# Altium schematic sheet RC_Board.SchDoc(RC_Board)
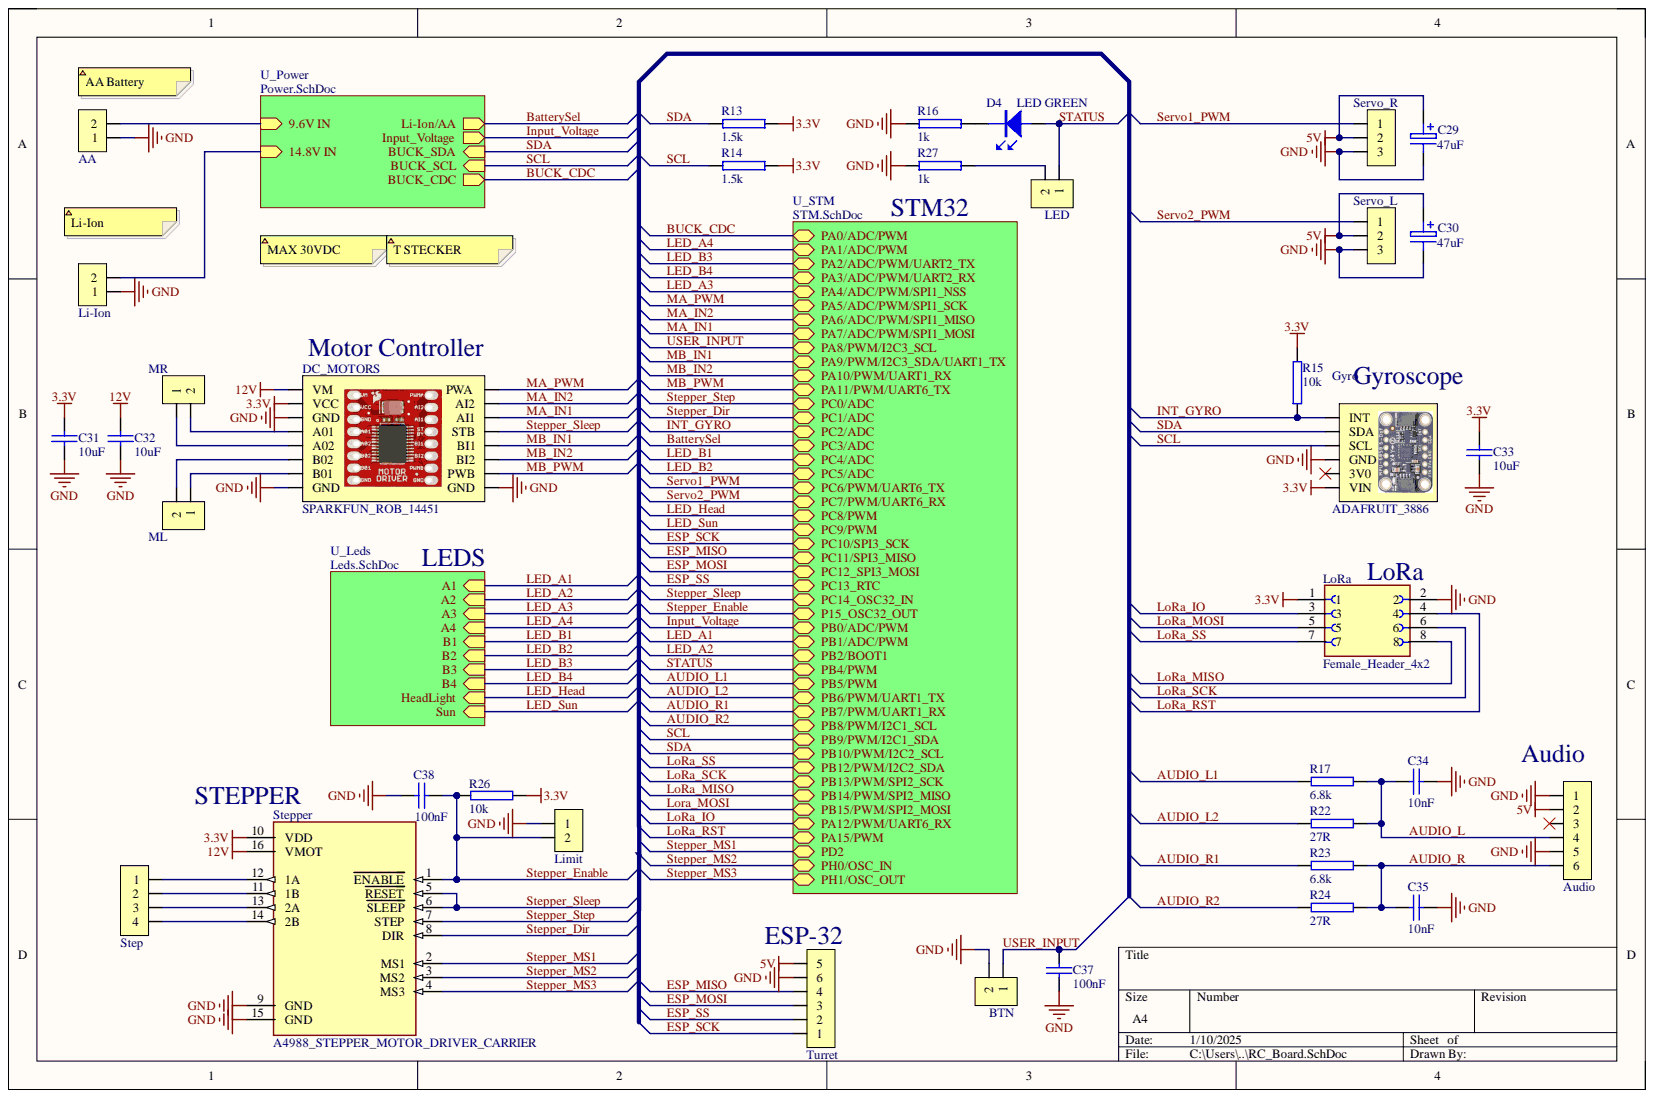
[diagram p0: RC_Board.SchDoc]

## Parts
AA  2Pin_Female  [OSTTE020104]  (value not drawn)
Audio  6Pin_Male  [Header1x6_254]  (value not drawn)
BTN  2Pin_Male  [Header1x2_254]  (value not drawn)
C29  value 47uF  [HA1C470WR]  (hidden comment: C 47uF ALUM)
C30  value 47uF  [HA1C470WR]  (hidden comment: C 47uF ALUM)
C31  value 10uF  [0603]  (hidden comment: C 10uF 0603)
C32  value 10uF  [0603]  (hidden comment: C 10uF 0603)
C33  value 10uF  [0603]  (hidden comment: C 10uF 0603)
C34  value 10nF  [0603]  (hidden comment: C 10nF 0603)
C35  value 10nF  [0603]  (hidden comment: C 10nF 0603)
C37  value 100nF  [0603]  (hidden comment: C 100nF 0603)
C38  value 100nF  [0603]  (hidden comment: C 100nF 0603)
D4  LED GREEN  [LED_1206]
DC_MOTORS  SPARKFUN_ROB_14451  [SPARKFUN_ROB_14451]
Gyro  ADAFRUIT_3886  [ADAFRUIT_3886]
LED  2Pin_Male  [Header1x2_254]  (value not drawn)
Li-Ion  2Pin_Female  [OSTTE020104]  (value not drawn)
Limit  2Pin_Male  [Header1x2_254]  (value not drawn)
LoRa  Female_Header_4x2  [SULLINS_PPPC042LFBN-RC]
ML  2Pin_Male  [Header1x2_254]  (value not drawn)
MR  2Pin_Male  [Header1x2_254]  (value not drawn)
R13  value 1.5k  [0805]  (hidden comment: R 1K5 0805)
R14  value 1.5k  [0805]  (hidden comment: R 1K5 0805)
R15  value 10k  [0805]  (hidden comment: R 10K 0805)
R16  value 1k  [0805]  (hidden comment: R 1K 0805)
R17  value 6.8k  [0805]  (hidden comment: R 6K8 0805)
R22  value 27R  [0805]  (hidden comment: R 27R 0805)
R23  value 6.8k  [0805]  (hidden comment: R 6K8 0805)
R24  value 27R  [0805]  (hidden comment: R 27R 0805)
R26  value 10k  [0805]  (hidden comment: R 10K 0805)
R27  value 1k  [0805]  (hidden comment: R 1K 0805)
Servo_L  3Pin_Male  [Header1x3_254]  (value not drawn)
Servo_R  3Pin_Male  [Header1x3_254]  (value not drawn)
Step  4Pin_Male  [Header1x4_254]  (value not drawn)
Stepper  A4988_STEPPER_MOTOR_DRIVER_CARRIER  [MODULE_A4988_STEPPER_MOTOR_DRIVER_CARRIER]
Turret  6Pin_Male  [Header1x6_254]  (value not drawn)

## Nets
3.3V: C31.1 C33.1 DC_MOTORS.2(VCC) Gyro.1(VIN) LoRa.1 R13.2 R14.2 R15.2 R26.2 Stepper.10(VDD)
5V: Audio.2 C29.1 C30.1 Servo_L.2 Servo_R.2 Turret.5
12V: C32.1 DC_MOTORS.1(VM) Stepper.16(VMOT)
GND: AA.1 Audio.1 Audio.5 BTN.2 C29.2 C30.2 C31.2 C32.2 C33.2 C34.2 C35.2 C37.2 C38.1 DC_MOTORS.3(GND) DC_MOTORS.8(GND) DC_MOTORS.9(GND) Gyro.3(GND) Li-Ion.1 Limit.1 LoRa.2 R16.1 R27.1 Servo_L.3 Servo_R.3 Stepper.9(GND) Stepper.15(GND) Turret.6
AUDIO_L: Audio.4 C34.1 R17.2 R22.2
AUDIO_L1: R17.1
AUDIO_L2: R22.1
AUDIO_R: Audio.6 C35.1 R23.2 R24.2
AUDIO_R1: R23.1
AUDIO_R2: R24.1
ESP_MISO: Turret.4
ESP_MOSI: Turret.3
ESP_SCK: Turret.1
ESP_SS: Turret.2
INT_GYRO: Gyro.6(INT) R15.1
LoRa_IO: LoRa.3
LoRa_MISO: LoRa.8
Lora_MOSI: LoRa.5
LoRa_RST: LoRa.4
LoRa_SCK: LoRa.6
LoRa_SS: LoRa.7
MA_IN1: DC_MOTORS.14(AI1)
MA_IN2: DC_MOTORS.15(AI2)
MA_PWM: DC_MOTORS.16(PWA)
MB_IN1: DC_MOTORS.12(BI1)
MB_IN2: DC_MOTORS.11(BI2)
MB_PWM: DC_MOTORS.10(PWB)
SCL: Gyro.4(SCL) R14.1
SDA: Gyro.5(SDA) R13.1
Servo1_PWM: Servo_R.1
Servo2_PWM: Servo_L.1
STATUS: D4.A LED.1
Stepper_Dir: Stepper.8(DIR)
Stepper_Enable: C38.2 Limit.2 R26.1 Stepper.1(ENABLE)
Stepper_MS1: Stepper.2(MS1)
Stepper_MS2: Stepper.3(MS2)
Stepper_MS3: Stepper.4(MS3)
Stepper_Sleep: DC_MOTORS.13(STB) Stepper.5(RESET) Stepper.6(SLEEP)
Stepper_Step: Stepper.7(STEP)
USER_INPUT: BTN.1 C37.1
NetAA_2: AA.2
NetAudio_3: Audio.3
NetD4_K: D4.K R16.2
NetDC_MOTORS_4: DC_MOTORS.4(A01) MR.2
NetDC_MOTORS_5: DC_MOTORS.5(A02) MR.1
NetDC_MOTORS_6: DC_MOTORS.6(B02) ML.2
NetDC_MOTORS_7: DC_MOTORS.7(B01) ML.1
NetGyro_2: Gyro.2(3V0)
NetLED_2: LED.2 R27.2
NetLi-Ion_2: Li-Ion.2
NetStep_1: Step.1 Stepper.12(1A)
NetStep_2: Step.2 Stepper.11(1B)
NetStep_3: Step.3 Stepper.13(2A)
NetStep_4: Step.4 Stepper.14(2B)
BatterySel:
BUCK_CDC:
Input_Voltage:
LED_A1:
LED_A2:
LED_A3:
LED_A4:
LED_B1:
LED_B2:
LED_B3:
LED_B4:
LED_Head:
LED_Sun:

## Library data of the parts
AA Li-Ion = Terminal_2Pin: Database Table Name: Connectors; Component Kind: Standard; ComponentLink1Description: Datasheet; ComponentLink1URL: https://www.on-shore.com/wp-content/uploads/OSTTEXX0104.pdf; Part ID: Terminal_2Pin; Price 1pc (CHF): 0.69; Supplier 1: Digi-Key; Supplier Part Number 1: ED2740-ND; Library Name: FHGR_Altium_database.DbLib
Audio Turret = ArduinoConnector_6: Database Table Name: Connectors; Component Kind: Standard; ComponentLink1Description: Datasheet; ComponentLink1URL: https://katalog.we-online.de/em/datasheet/6130xx11121.pdf; Part ID: ArduinoConnector_6; Price 1pc (CHF): 0.32; Supplier 1: Digi-Key; Supplier Part Number 1: 732-5319-ND; Library Name: FHGR_Altium_database.DbLib
BTN LED Limit = Header_2: Database Table Name: Connectors; Component Kind: Standard; ComponentLink1Description: Datasheet; ComponentLink1URL: https://katalog.we-online.de/em/datasheet/6130xx11121.pdf; Part ID: Header_2; Price 1pc (CHF): 0.13; Supplier 1: Digi-Key; Supplier Part Number 1: 732-5315-ND; Library Name: FHGR_Altium_database.DbLib
C29 C30 = C_47uF_ALUM: Database Table Name: Capacitors; Tolerance: 20%; Voltage: 16V; Component Kind: Standard; Part ID: C_47uF_ALUM; Price 1pc (CHF): 0.08; Signal Integrity: Model Name; Simulation: Model Name; Supplier 1: Digi-Key; Supplier Part Number 1: PCE4190TR-ND; Library Name: FHGR_Altium_database.DbLib
C31-C33 = C_10uF_0603: Database Table Name: Capacitors; TempKoeff: X5R; Tolerance: 10%; Voltage: 16V; Component Kind: Standard; Part ID: C_10uF_0603; Price 1pc (CHF): 0.40; Signal Integrity: SignalIntegrity; Simulation: Model Name; Supplier 1: Digi-Key; Supplier Part Number 1: 490-12317-1-ND; Library Name: FHGR_Altium_database.DbLib
C34 C35 = C_10000pF_0603: Database Table Name: Capacitors; TempKoeff: X7R; Tolerance: 10%; Voltage: 50V; Component Kind: Standard; Part ID: C_10000pF_0603; Price 1pc (CHF): 0.10; Signal Integrity: SignalIntegrity; Simulation: Model Name; Supplier 1: Digi-Key; Supplier Part Number 1: 1276-1009-1-ND; Library Name: FHGR_Altium_database.DbLib
C37 C38 = C_100nF_0603: Database Table Name: Capacitors; TempKoeff: Y5V; Tolerance: -20%/+80%; Voltage: 50V; Component Kind: Standard; Part ID: C_100nF_0603; Price 1pc (CHF): 0.1; Signal Integrity: SignalIntegrity; Simulation: Model Name; Supplier 1: Digi-Key; Supplier Part Number 1: 1276-1012-1-ND; Library Name: FHGR_Altium_database.DbLib
D4 = LED_GREEN_1206: Database Table Name: Optoelectronics; Peak Wavelenght: 565nm; Component Kind: Standard; ComponentLink1Description: Datasheet; ComponentLink1URL: https://media.digikey.com/pdf/Data%20Sheets/Lite-On%20PDFs/LTST-C230KGKT.pdf; Part ID: LED_GREEN_1206; Price 10pcs: 0.226; Signal Integrity: SignalIntegrity; Supplier 1: Digi-Key; Supplier Part Number 1: 67-1357-1-ND; Library Name: FHGR_Altium_database.DbLib
DC_MOTORS = DC_Motor_Driver: CMP-037-000021-1  Dual Motor Driver; Buchse: 2 x SAM1213-08-ND; Stecker: 2 x 732-5321-ND; Component Kind: Standard; ComponentLink1Description: Datasheet; ComponentLink1URL: https://media.digikey.com/pdf/Data%20Sheets/Sparkfun%20PDFs/ROB-14451_Web.pdf; Part ID: SPARKFUN_ROB_14451; Price 1pc (CHF): 4.5; Library Name: FritzCustomComponets.IntLib
Gyro = Gyro Kebab: CMP-037-000034-1  MPU-6050 6-DOF; Buchse: SAM1213-06-ND; Stecker: 732-5319-ND; Component Kind: Standard; ComponentLink1Description: Datasheet; ComponentLink1URL: https://www.mouser.ch/datasheet/2/737/mpu6050_6_dof_accelerometer_and_gyro-2489019.pdf; Part ID: ADAFRUIT_3886; Price 1pc (CHF): 12.82; Library Name: FritzCustomComponets.IntLib
LoRa = Female_Header_4x2: 8 Position Header Connector 0.100 (2.54mm) Through Hole Gold; MF: Sullins Connector Solutions; MP: PPPC042LFBN-RC; Availability: In Stock; Check_prices: https://www.snapeda.com/parts/PPPC042LFBN-RC/Sullins+Connector+Solutions/view-part/?ref=eda; Component Kind: Standard; Package: None; Price: None; Purchase-URL: https://pricing.snapeda.com/search/part/PPPC042LFBN-RC/?ref=eda; SnapEDA_Link: https://www.snapeda.com/parts/PPPC042LFBN-RC/Sullins+Connector+Solutions/view-part/?ref=snap; Library Name: FritzCustomComponets.IntLib
ML MR = Header_2: Database Table Name: Connectors; System: Connector; Component Kind: Standard; ComponentLink1Description: Datasheet; ComponentLink1URL: https://katalog.we-online.de/em/datasheet/6130xx11121.pdf; Part ID: Header_2; Price 1pc (CHF): 0.13; Supplier 1: Digi-Key; Supplier Part Number 1: 732-5315-ND; Library Name: FHGR_Altium_database.DbLib
R13 R14 = R_1k5_0805: Database Table Name: Resistors; Power Dissipation: 250mW; Tolerance: 1%; Component Kind: Standard; Part ID: R_1k5_0805; Price 10pcs (CHF): 0.072; Signal Integrity: SignalIntegrity; Simulation: Model Name; Supplier 1: Digi-Key; Supplier Part Number 1: RNCP0805FTD1K50CT-ND; Library Name: FHGR_Altium_database.DbLib
R15 R26 = R_10k_0805: Database Table Name: Resistors; Power Dissipation: 125mW; Tolerance: 1%; Component Kind: Standard; Part ID: R_10k_0805; Price 10pcs (CHF): 0.021; Signal Integrity: SignalIntegrity; Simulation: Model Name; Supplier 1: Digi-Key; Supplier Part Number 1: 311-10.0KCRCT-ND; Library Name: FHGR_Altium_database.DbLib
R16 R27 = R_1k_0805: Database Table Name: Resistors; Power Dissipation: 125mW; Tolerance: 1%; Component Kind: Standard; Part ID: R_1k_0805; Price 10pcs (CHF): 0.021; Signal Integrity: SignalIntegrity; Simulation: Model Name; Supplier 1: Digi-Key; Supplier Part Number 1: 311-1.00KCRCT-ND; Library Name: FHGR_Altium_database.DbLib
R17 R23 = R_6k8_0805: Database Table Name: Resistors; Power Dissipation: 125mW; Tolerance: 1%; Component Kind: Standard; Part ID: R_6k8_0805; Price 10pcs (CHF): 0.068; Signal Integrity: SignalIntegrity; Simulation: Model Name; Supplier 1: Digi-Key; Supplier Part Number 1: A126384CT-ND; Library Name: FHGR_Altium_database.DbLib
R22 R24 = R_27R_0805: Database Table Name: Resistors; Power Dissipation: 125mW; Tolerance: 1%; Component Kind: Standard; Part ID: R_27R_0805; Price 10pcs (CHF): 0.042; Signal Integrity: SignalIntegrity; Simulation: Model Name; Supplier 1: Digi-Key; Supplier Part Number 1: 311-27.0CRCT-ND; Library Name: FHGR_Altium_database.DbLib
Servo_L Servo_R = Header_3: Database Table Name: Connectors; System: Connector; Component Kind: Standard; ComponentLink1Description: Datasheet; ComponentLink1URL: https://www.we-online.com/katalog/datasheet/6130xx11121.pdf; Part ID: Header_3; Price 1pc (CHF): 0.12; Supplier 1: Digi-Key; Supplier Part Number 1: 732-5316-ND; Library Name: FHGR_Altium_database.DbLib
Step = Header_4: Database Table Name: Connectors; Component Kind: Standard; ComponentLink1Description: Datasheet; ComponentLink1URL: https://www.we-online.com/katalog/datasheet/6130xx11121.pdf; Part ID: Header_4; Price 1pc (CHF): 0.16; Supplier 1: Digi-Key; Supplier Part Number 1: 732-5317-ND; Library Name: FHGR_Altium_database.DbLib
Stepper = A4988_STEPPER_MOTOR_DRIVER_CARRIER: Stepper motor controler; IC: A4988; 1A; Uin mot: 8Ã·35V; Availability: Unavailable; Component Kind: Standard; MP: A4988_STEPPER_MOTOR_DRIVER_CARRIER; Package: None; Price: None; Library Name: FritzCustomComponets.IntLib
